# Altium schematic sheet motor_controller_relays.SchDoc(motor_controller_relays)
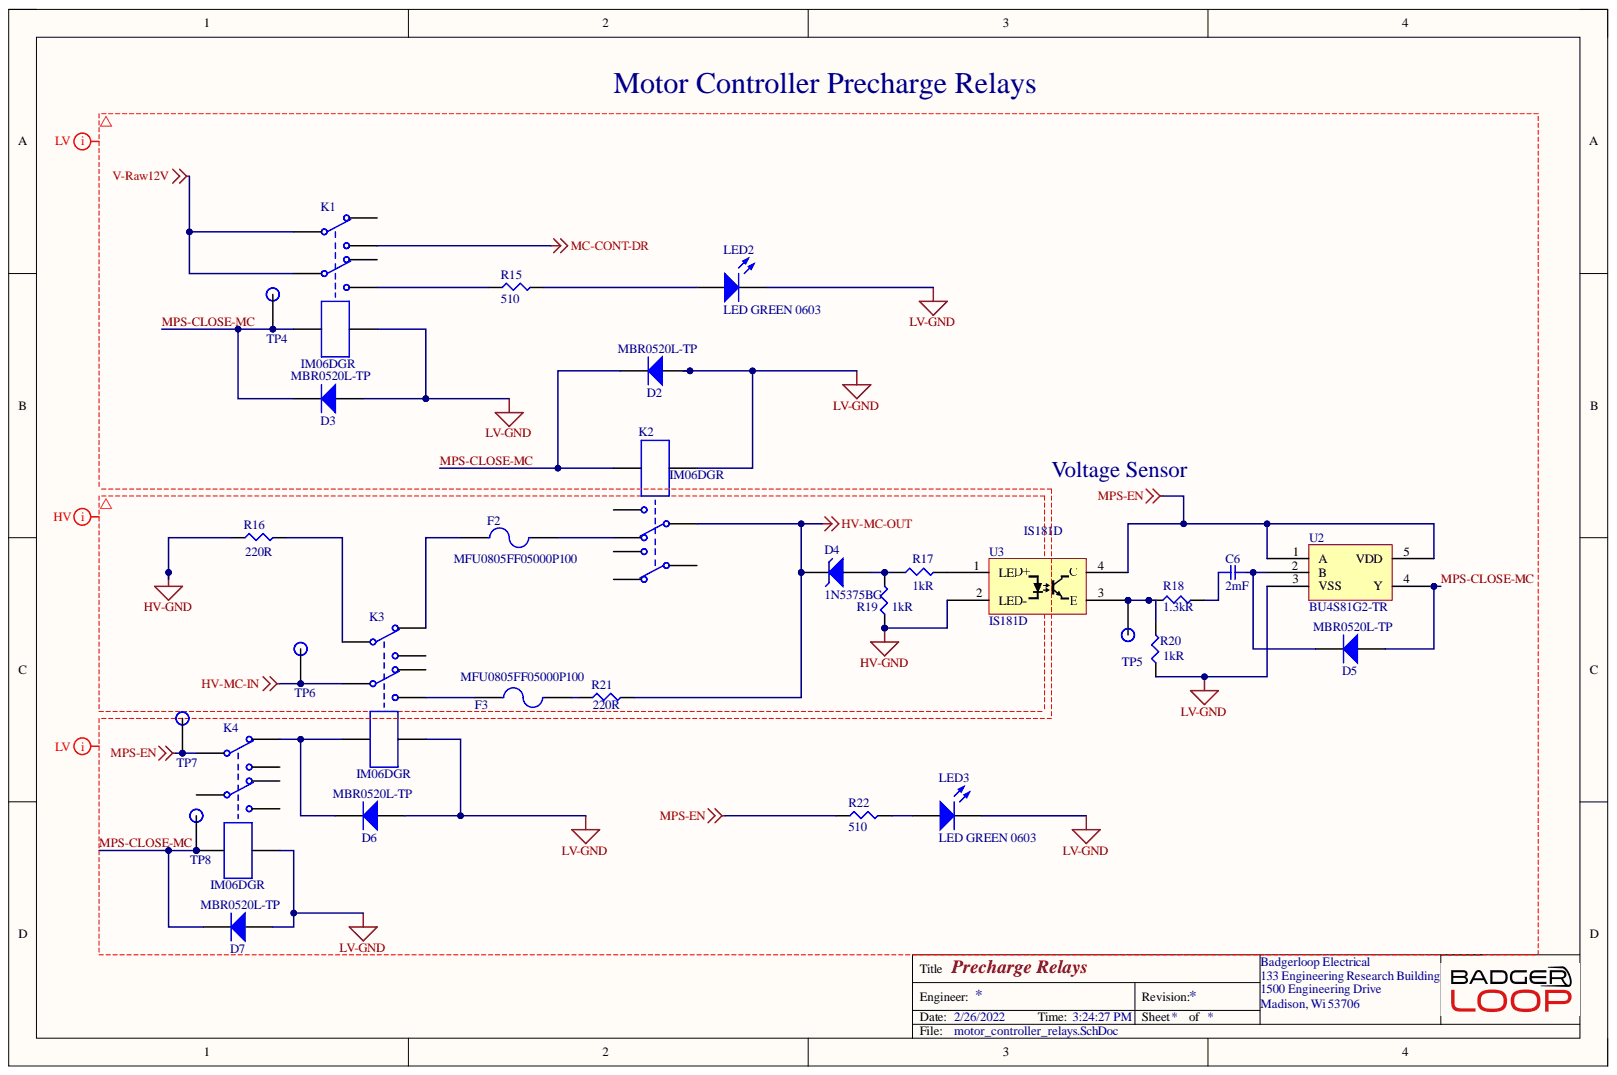
[diagram p0: motor_controller_relays.SchDoc]

## Parts
C6  Capacitance: 2mF  [EEU-TP1E202S]  (hidden comment: EEU-TP1E202S)
D2  MBR0520L-TP  [MBR0520LT1G - SOD123]
D3  MBR0520L-TP  [MBR0520LT1G - SOD123]
D4  1N5375BG  [1N5375BG]
D5  MBR0520L-TP  [MBR0520LT1G - SOD123]
D6  MBR0520L-TP  [MBR0520LT1G - SOD123]
D7  MBR0520L-TP  [MBR0520LT1G - SOD123]
F2  MFU0805FF05000P100  [SMD-0805-RES]
F3  MFU0805FF05000P100  [SMD-0805-RES]
K1  Manufacturer Part Number: IM06DGR  [1-1462039-8 - relay]  (hidden comment: 1-1462039-8)
K2  Manufacturer Part Number: IM06DGR  [1-1462039-8 - relay]  (hidden comment: 1-1462039-8)
K3  Manufacturer Part Number: IM06DGR  [1-1462039-8 - relay]  (hidden comment: 1-1462039-8)
K4  Manufacturer Part Number: IM06DGR  [1-1462039-8 - relay]  (hidden comment: 1-1462039-8)
LED2  LED GREEN 0603  Color: GREEN  [LED 0603 GREEN]
LED3  LED GREEN 0603  Color: GREEN  [LED 0603 GREEN]
R15  RMCF1206FT510R  [SMD-1206-RES]  (value not drawn)
R16  Resistance: 220R  [TO-247-2]  (hidden comment: AP101220RJ)
R17  Resistance: 1kR  [SMD-1206-RES]  (hidden comment: D55342K07B1E00RS3)
R18  Resistance: 1.3kR  [SMD-1206-RES]  (hidden comment: ERA-8AEB132V)
R19  Resistance: 1kR  [SMD-1206-RES]  (hidden comment: D55342K07B1E00RS3)
R20  Resistance: 1kR  [SMD-1206-RES]  (hidden comment: D55342K07B1E00RS3)
R21  Resistance: 220R  [TO-247-2]  (hidden comment: AP101220RJ)
R22  RMCF1206FT510R  [SMD-1206-RES]  (value not drawn)
TP4  TP  [Test Point]
TP5  TP  [Test Point]
TP6  TP  [Test Point]
TP7  TP  [Test Point]
TP8  TP  [Test Point]
U2  BU4S81G2-TR  [BU4S81G2]
U3  IS181D  [IS181D]

## Nets
HV-GND: R16.1 R19.1 U3.2(LED-)
HV-MC-IN: K3.6 TP6.1
HV-MC-OUT: D4.1 K2.6 R21.2
LV-GND: D2.1 D3.1 D6.1 D7.1 K1.8 K2.1 K3.8 K4.8 LED2.2 LED3.2 R20.1 U2.3(VSS)
MC-CONT-DR: K1.4
MPS-EN: K4.3 R22.1 TP7.1 U2.1(A) U2.5(VDD) U3.4(C)
V-Raw12V: K1.3 K1.6
MPS-CLOSE-MC: D2.2 D3.2 D5.1 D7.2 K1.1 K2.8 K4.1 TP4.1 TP8.1 U2.4(Y)
NetC6_1: C6.1 R18.2
NetC6_2: C6.2 D5.2 U2.2(B)
NetD4_2: D4.2 R17.1 R19.2
NetD6_2: D6.2 K3.1 K4.2
NetF2_1: F2.1 K3.2
NetF2_2: F2.2 K2.7
NetF3_1: F3.1 K3.5
NetF3_2: F3.2 R21.1
NetK1_5: K1.5 R15.1
NetK3_3: K3.3 R16.2
NetLED2_1: LED2.1 R15.2
NetLED3_1: LED3.1 R22.2
NetR17_2: R17.2 U3.1(LED+)
NetR18_1: R18.1 R20.2 TP5.1 U3.3(E)

Not connected: K1 2 7; K2 2 3 4 5; K3 4 7; K4 4 5 6 7

## Library data of the parts
C6 = EEU-TP1E202S: Aluminum Electrolytic Capacitor, 25V, 2000uF; Manufacturer: Panasonic; Capacitor Type: Aluminium; ESR (Equivalent Series Resistance): 0.029mR; Leakage Current: 500uA; Negative Tolerance: 20%; Polarity: Polarized; Reference Standard: AEC-Q200; Ripple Current: 1.588A; Tan Delta: 0.16; Category: Aluminum Electrolytic Capacitors; Component Kind: Standard; ComponentLink1Description: https://datasheet.ciiva.com:443/pdfs/VipMasterIC/IC/PANA/PANA-S-A0009459718/PANA-S-A0009459718-1.pdf?src-supplier=IHS+Markit; ComponentLink1URL: https://datasheet.ciiva.com:443/pdfs/VipMasterIC/IC/PANA/PANA-S-A0009459718/PANA-S-A0009459718-1.pdf?src-supplier=IHS+Markit; ComponentLink2Description: https://datasheet.ciiva.com/11784/0900766b80f8045d-11784485.pdf?src-supplier=RSComponents; ComponentLink2URL: https://datasheet.ciiva.com/11784/0900766b80f8045d-11784485.pdf?src-supplier=RSComponents; ComponentLink3Description: https://datasheet.ciiva.com/14607/70257784-14607977.pdf?src-supplier=Allied+Electronics; ComponentLink3URL: https://datasheet.ciiva.com/14607/70257784-14607977.pdf?src-supplier=Allied+Electronics; ComponentLink4Description: https://datasheet.ciiva.com/11677/aba0000ce134-11677886.pdf?src-supplier=Avnet; ComponentLink4URL: https://datasheet.ciiva.com/11677/aba0000ce134-11677886.pdf?src-supplier=Avnet; Diameter: 16mm; Dielectric Material: Aluminium; JESD-609 Code: e3; Length: 20mm; Library Name: capacitors.SchLib; Manufacturer Part Number: EEU-TP1E202S; Max Operating Temperature: 135°C; Min Operating Temperature: -40°C; Mount: Through Hole; Number of Pins: 2; Package Style: RadialMeter; Packaging: Bulk; Positive Tolerance: 20%; Pricing 1: 1=3.19000, 10=2.58000, 50=2.25160, 100=1.97010, 500=1.50100, 1000=1.31338, 2500=1.26647, 5000=1.21956 (USD); Rated DC Voltage (URdc): 25V; REACH SVHC: Yes; RoHS: Yes; RoHS Compliant: Yes; Stock 1: 672; Supplier 1: Digi-Key; Supplier Part Number 1: P14922-ND; Terminal Finish: Tin; Terminal Pitch: 7.5mm; Termination: Wire
D2 D3 D5-D7 = MBR0520L-TP: Rectifier Diode, Schottky, 1 Phase, 1 Element, 0.5A, 20V V(RRM), Silicon; Manufacturer: MCC; Forward Voltage-Max (VF): 300mV; Max Output Current: 500mA; Max Power Dissipation: 410mW; Non-rep Pk Forward Current-Max: 5.5A; Number of Elements: 1; Package Body Material: Plastic; Qualification Status: Not Qualified; Time @ Peak Reflow Temperature-Max (s): 10s; Category: Rectifier Diodes; Component Kind: Standard; ComponentLink1Description: https://datasheet.ciiva.com:443/pdfs/VipMasterIC/IC/MCCC/MCCC-S-A0007607609/MCCC-S-A0007607609-1.pdf?src-supplier=IHS+Markit; ComponentLink1URL: https://datasheet.ciiva.com:443/pdfs/VipMasterIC/IC/MCCC/MCCC-S-A0007607609/MCCC-S-A0007607609-1.pdf?src-supplier=IHS+Markit; ComponentLink2Description: https://datasheet.ciiva.com/24106/mbr0520lmbr0540lsod-123-276512-24106653.pdf?src-supplier=Mouser; ComponentLink2URL: https://datasheet.ciiva.com/24106/mbr0520lmbr0540lsod-123-276512-24106653.pdf?src-supplier=Mouser; ComponentLink3Description: https://datasheet.ciiva.com/6381/mbr0520lmbr0540lsod-123-6381109.pdf?src-supplier=Digi-Key; ComponentLink3URL: https://datasheet.ciiva.com/6381/mbr0520lmbr0540lsod-123-6381109.pdf?src-supplier=Digi-Key; Diode Element Material: SILICON; Diode Type: RECTIFIER; JESD-30 Code: R-PDSO-G2; JESD-609 Code: e3; Library Name: misc.SchLib; Manufacturer Part Number: MBR0520L-TP; Max Operating Temperature: 125°C; Moisture Sensitivity Level: 1; Number of Pins: 2; Package Shape: Rectangular; Package Style: SMALL OUTLINEMeter; Peak Reflow Temperature (Cel): 260°C; Pricing 1: 1=0.52000, 10=0.38700, 100=0.21930, 500=0.14520, 1000=0.11132 (USD); REACH SVHC: Yes; Rep Pk Reverse Voltage-Max: 20V; RoHS: Yes; RoHS Compliant: Yes; Stock 1: 75810; Supplier 1: Digi-Key; Supplier Part Number 1: MBR0520L-TPMSCT-ND; Surface Mount: Yes; Technology: SCHOTTKY; Terminal Finish: Tin; Terminal Form: Gull Wing; Terminal Position: DUAL
D4 = 1N5375BG: Zener Diode, 82V V(Z), 5%, 5W, Silicon, Unidirectional; Manufacturer: ON Semiconductor; Case Connection: Isolated; Dynamic Impedance-Max: 65R; Number of Elements: 1; Package Body Material: Plastic; Qualification Status: Not Qualified; Surface Mount: No; Test Current: 15mA; Time @ Peak Reflow Temperature-Max (s): 40s; Category: Zener Diodes; Component Kind: Standard; ComponentLink1Description: https://datasheet.ciiva.com:443/pdfs/VipMasterIC/IC/ONSM/ONSMS36970/ONSMS36970-1.pdf?src-supplier=IHS+Markit; ComponentLink1URL: https://datasheet.ciiva.com:443/pdfs/VipMasterIC/IC/ONSM/ONSMS36970/ONSMS36970-1.pdf?src-supplier=IHS+Markit; ComponentLink2Description: https://datasheet.ciiva.com/30478/0900766b811a6372-30478537.pdf?src-supplier=RSComponents; ComponentLink2URL: https://datasheet.ciiva.com/30478/0900766b811a6372-30478537.pdf?src-supplier=RSComponents; ComponentLink3Description: https://datasheet.ciiva.com/15142/getdatasheetpartid-72158-15142393.pdf?src-supplier=Verical; ComponentLink3URL: https://datasheet.ciiva.com/15142/getdatasheetpartid-72158-15142393.pdf?src-supplier=Verical; ComponentLink4Description: https://datasheet.ciiva.com/895/1n5333b-d-895438.pdf?src-supplier=Digi-Key; ComponentLink4URL: https://datasheet.ciiva.com/895/1n5333b-d-895438.pdf?src-supplier=Digi-Key; ComponentLink5Description: https://datasheet.ciiva.com/8345/1n5333b-d-8345830.pdf?src-supplier=Avnet; ComponentLink5URL: https://datasheet.ciiva.com/8345/1n5333b-d-8345830.pdf?src-supplier=Avnet; ComponentLink6Description: https://datasheet.ciiva.com/1182/56785-1182241.pdf?src-supplier=Element14; ComponentLink6URL: https://datasheet.ciiva.com/1182/56785-1182241.pdf?src-supplier=Element14; ComponentLink7Description: https://datasheet.ciiva.com/14558/70099451-14558283.pdf?src-supplier=Allied+Electronics; ComponentLink7URL: https://datasheet.ciiva.com/14558/70099451-14558283.pdf?src-supplier=Allied+Electronics; ComponentLink8Description: https://datasheet.ciiva.com/46425/1n5333b-d-46425850.pdf?src-supplier=Element14; ComponentLink8URL: https://datasheet.ciiva.com/46425/1n5333b-d-46425850.pdf?src-supplier=Element14; Diode Element Material: SILICON; Diode Type: ZENER; JESD-30 Code: O-PALF-W2; JESD-609 Code: e3; Library Name: misc.SchLib; Max Operating Temperature: 200°C; Max Power Dissipation: 5W; Min Operating Temperature: -65°C; Number of Pins: 2; Package Shape: Round; Package Style: LONG FORMMeter; Peak Reflow Temperature (Cel): 260°C; Polarity: Unidirectional; Pricing 1: 1=0.47000, 10=0.40500, 100=0.30210, 500=0.23740, 1000=0.18344, 2000=0.17815 (USD); REACH SVHC: No SVHC; Reference Voltage-Nom: 82V; RoHS: Yes; RoHS Compliant: Yes; Stock 1: 1460; Supplier 1: Digi-Key; Supplier Part Number 1: 1N5375BGOS-ND; Technology: ZENER; Terminal Finish: Tin; Terminal Form: Wire; Terminal Position: AXIAL; Voltage Tol-Max: 5%
F2 F3 = MFU0805FF05000P100: Electric Fuse, Very Fast Blow, 5A, 32VDC, 50A (IR), Surface Mount; Manufacturer: Vishay; Body Breadth: 1.25inch; Body Height: 0.45mm; Body Length or Diameter: 2mm; Joule-integral-Nom: 0.2275J; Reference Standard: UL; Voltage Rating (DC): 32V; Blow Characteristic: VERY FAST; Category: Fuses; Circuit Protection Type: Electric Fuse; Component Kind: Standard; ComponentLink1Description: https://datasheet.ciiva.com:443/pdfs/VipMasterIC/IC/VISH/VISH-S-A0001809179/VISH-S-A0001809179-1.pdf?src-supplier=IHS+Markit; ComponentLink1URL: https://datasheet.ciiva.com:443/pdfs/VipMasterIC/IC/VISH/VISH-S-A0001809179/VISH-S-A0001809179-1.pdf?src-supplier=IHS+Markit; ComponentLink2Description: https://datasheet.ciiva.com/1730/mfuserie-1730720.pdf?src-supplier=Newark; ComponentLink2URL: https://datasheet.ciiva.com/1730/mfuserie-1730720.pdf?src-supplier=Newark; ComponentLink3Description: https://datasheet.ciiva.com/11337/mfuserie-11337721.pdf?src-supplier=Avnet; ComponentLink3URL: https://datasheet.ciiva.com/11337/mfuserie-11337721.pdf?src-supplier=Avnet; ComponentLink4Description: https://datasheet.ciiva.com/8057/1480005-8057917.pdf?src-supplier=Element14; ComponentLink4URL: https://datasheet.ciiva.com/8057/1480005-8057917.pdf?src-supplier=Element14; ComponentLink5Description: https://datasheet.ciiva.com/22734/1480005-22734093.pdf?src-supplier=Element14; ComponentLink5URL: https://datasheet.ciiva.com/22734/1480005-22734093.pdf?src-supplier=Element14; ComponentLink6Description: https://datasheet.ciiva.com/17414/mfuserie-17414775.pdf?src-supplier=Avnet+Europe; ComponentLink6URL: https://datasheet.ciiva.com/17414/mfuserie-17414775.pdf?src-supplier=Avnet+Europe; ComponentLink7Description: https://datasheet.ciiva.com/1730/mfuserie-1730721.pdf?src-supplier=Newark; ComponentLink7URL: https://datasheet.ciiva.com/1730/mfuserie-1730721.pdf?src-supplier=Newark; Current Rating: 5A; JESD-609 Code: e3; Library Name: misc.SchLib; Manufacturer Part Number: MFU0805FF05000P100; Max Operating Temperature: 35°C; Min Operating Temperature: 15°C; Mount: Surface Mount; Packaging: Tape and Reel; Pricing 1: 1=0.49000, 5=0.47600, 10=0.46200, 50=0.38880, 100=0.32270, 250=0.29340, 500=0.24940 (USD); Rated Breaking Capacity: 50A; REACH SVHC: Yes; RoHS: Yes; RoHS Compliant: Yes; Stock 1: 50547; Supplier 1: Digi-Key; Supplier Part Number 1: MFU08055.00CT-ND; Terminal Finish: Tin; Termination: Wraparound
K1-K4 = 1-1462039-8: Electromechanical Relay, DPDT, 140mW (Coil), 5A (Contact), 220VDC (Contact), Random, AC/DC Output; Manufacturer: TE Connectivity; Body Breadth: 6inch; Body Height: 5.65mm; Body Length or Diameter: 10mm; Coil Operate Voltage(DC): 9V; Coil Release Voltage(DC): 1.2V; Coil Resistance: 1.029kR; Coil Voltage-Nom: 12V; Coil/Input Supply Type: DC; Contact AC Max Power Rating R Load: 62.5VA@250VAC; Contact AC Max Rating R Load: 5A@250VAC; Contact DC Max Power Rating R Load: 60W@220VDC; Contact DC Max Rating R Load: 5A@220VDC; Contact Resistance: 50mR; Contact Voltage(AC)-Max: 250V; Contact Voltage(DC)-Max: 220V; Dielectric Strength Between Coil and Contacts: 1500Vrms; Dielectric Strength Between Open Contacts: 750Vrms; End Contact Material: Silver; End Contact Plating: Gold; Insulation Resistance: 1GR; Operate Time: 3ms; Reference Standard: UL; Relay Form: 2 FORM C; Sealing: HERMETICAL; Category: Power Relays; Coil Power: 140mW; Component Kind: Standard; ComponentLink1Description: https://datasheet.ciiva.com:443/pdfs/VipMasterIC/IC/TYEL/TYELS75403/TYELS75403-1.pdf?src-supplier=IHS+Markit; ComponentLink1URL: https://datasheet.ciiva.com:443/pdfs/VipMasterIC/IC/TYEL/TYELS75403/TYELS75403-1.pdf?src-supplier=IHS+Markit; ComponentLink2Description: https://datasheet.ciiva.com/1662/13778-1662593.pdf?src-supplier=Newark; ComponentLink2URL: https://datasheet.ciiva.com/1662/13778-1662593.pdf?src-supplier=Newark; ComponentLink3Description: https://datasheet.ciiva.com/1525/1717896-1525047.pdf?src-supplier=Element14; ComponentLink3URL: https://datasheet.ciiva.com/1525/1717896-1525047.pdf?src-supplier=Element14; ComponentLink4Description: https://datasheet.ciiva.com/1612/1717896-1612285.pdf?src-supplier=Farnell; ComponentLink4URL: https://datasheet.ciiva.com/1612/1717896-1612285.pdf?src-supplier=Farnell; ComponentLink5Description: https://datasheet.ciiva.com/30159/customerdrawing1462037-4a10-30159339.pdf?src-supplier=Onlinecomponents.com; ComponentLink5URL: https://datasheet.ciiva.com/30159/customerdrawing1462037-4a10-30159339.pdf?src-supplier=Onlinecomponents.com; ComponentLink6Description: https://datasheet.ciiva.com/22697/1717896-22697038.pdf?src-supplier=Element14; ComponentLink6URL: https://datasheet.ciiva.com/22697/1717896-22697038.pdf?src-supplier=Element14; Contact Current(AC)-Max: 5A; Contact Current(DC)-Max: 5A; Contact/Output Supply Type: AC/DC; Input Switching Control Type: Random; Library Name: misc.SchLib; Number of Pins: 8; Packaging: Tape and Reel; Pricing 1: 1=5.59000, 10=5.24500, 25=4.66200, 50=4.42880, 100=4.19580, 250=3.72960, 500=3.49650 (USD); REACH SVHC: No SVHC; Relay Function: DPDT; RoHS: No; RoHS Compliant: No; Stock 1: 35148; Supplier 1: Digi-Key; Supplier Part Number 1: PB1174CT-ND; Termination: Solder; Weight: 0.75g
LED2 LED3 = LED GREEN 0603: Manufacturer: Lite-On Inc.; Manufacturer P/N: LTST-C190KGKT; Component Kind: Standard; Digikey P/N: 160-1435-1-ND; Library Name: misc.SchLib; Package / Case: 0603
R15 R22 = RMCF1206FT510R: Fixed Resistor, Metal Glaze/thick Film, 0.25W, 510ohm, 200V, 1% +/-Tol, 100ppm/Cel, Surface Mount, 1206; Manufacturer: Stackpole Electronics; Package Height: 0.55mm; Package Length: 3.2mm; Package Width: 1.6mm; Rated Power Dissipation (P): 250mW; Rated Temperature: 70°C; Reference Standard: AEC-Q200; Resistance: 510; Temperature Coefficient: 100ppm/°C; Category: Chip SMD Resistors; Component Kind: Standard; ComponentLink1Description: https://datasheet.ciiva.com:443/pdfs/VipMasterIC/IC/SEIE/SEIE-S-A0009541969/SEIE-S-A0009541969-1.pdf?src-supplier=IHS+Markit; ComponentLink1URL: https://datasheet.ciiva.com:443/pdfs/VipMasterIC/IC/SEIE/SEIE-S-A0009541969/SEIE-S-A0009541969-1.pdf?src-supplier=IHS+Markit; ComponentLink2Description: http://components.arrow.com/part/dataview/40948710S9551678N1174?src-supplier=Arrow+Electronics; ComponentLink2URL: http://components.arrow.com/part/dataview/40948710S9551678N1174?src-supplier=Arrow+Electronics; ComponentLink3Description: https://www.seielect.com/Catalog/SEI-RMCF_RMCP.pdf?src-supplier=Digi-Key; ComponentLink3URL: https://www.seielect.com/Catalog/SEI-RMCF_RMCP.pdf?src-supplier=Digi-Key; ComponentLink4Description: https://www.seielect.com/catalog/SEI-Packaging.pdf?src-supplier=Digi-Key; ComponentLink4URL: https://www.seielect.com/catalog/SEI-Packaging.pdf?src-supplier=Digi-Key; ComponentLink5Description: https://www.seielect.com/catalog/SEI-RMCF_RMCP.pdf?src-supplier=Avnet; ComponentLink5URL: https://www.seielect.com/catalog/SEI-RMCF_RMCP.pdf?src-supplier=Avnet; Construction: Rectangular; JESD-609 Code: e3; Library Name: resistors.SchLib; Manufacturer Part Number: RMCF1206FT510R; Max Operating Temperature: 155°C; Min Operating Temperature: -55°C; Mount: Surface Mount; Number of Pins: 2; Package Shape: Rectangular; Package Style: SMTMeter; Packaging: Tape and Reel; Pricing 1: 1=0.10000, 10=0.03600, 100=0.01460, 1000=0.00655, 2500=0.00569 (USD); REACH SVHC: Yes; Resistor Type: FIXED RESISTOR; RoHS: Yes; RoHS Compliant: Yes; Size Code: 1206; Stock 1: 51; Supplier 1: Digi-Key; Supplier Part Number 1: RMCF1206FT510RCT-ND; Technology: METAL GLAZE/THICK FILM; Terminal Finish: Nickel; Termination: Wraparound; Tolerance: 1%; Working Voltage: 200V
R16 R21 = AP101220RJ: Fixed Resistor, 100W, 220ohm, 700V, 5% +/-Tol, 100ppm/Cel, Through Hole Mount; Manufacturer: Ohmite; Rated Power Dissipation (P): 3.5W; Rated Temperature: 25°C; Temperature Coefficient: 100ppm/°C; Category: Through-Hole Resistors; Component Kind: Standard; ComponentLink1Description: https://datasheet.ciiva.com:443/pdfs/VipMasterIC/IC/OMIT/OMIT-S-A0001298920/OMIT-S-A0001298920-1.pdf?src-supplier=IHS+Markit; ComponentLink1URL: https://datasheet.ciiva.com:443/pdfs/VipMasterIC/IC/OMIT/OMIT-S-A0001298920/OMIT-S-A0001298920-1.pdf?src-supplier=IHS+Markit; ComponentLink2Description: http://www.farnell.com/datasheets/2015590.pdf?src-supplier=Farnell; ComponentLink2URL: http://www.farnell.com/datasheets/2015590.pdf?src-supplier=Farnell; ComponentLink3Description: http://www.mouser.com/ds/2/303/acl_ap101-773331.pdf?src-supplier=Mouser; ComponentLink3URL: http://www.mouser.com/ds/2/303/acl_ap101-773331.pdf?src-supplier=Mouser; ComponentLink4Description: http://www.ohmite.com/cat/acl_ap101.pdf?src-supplier=Digi-Key; ComponentLink4URL: http://www.ohmite.com/cat/acl_ap101.pdf?src-supplier=Digi-Key; Library Name: resistors.SchLib; Manufacturer Part Number: AP101220RJ; Max Operating Temperature: 175°C; Min Operating Temperature: -65°C; Mount: Through Hole; Number of Pins: 2; Package Shape: Rectangular; Pricing 1: 1=6.63000, 10=6.21800, 35=5.80343, 70=5.11271, 105=4.42171, 245=4.00722, 455=3.73086, 945=3.67501 (USD); REACH SVHC: Yes; Resistor Type: FIXED RESISTOR; RoHS: Yes; RoHS Compliant: Yes; Stock 1: 44; Supplier 1: Digi-Key; Supplier Part Number 1: AP101220RJ-ND; Termination: Flat; Tolerance: 5%; Working Voltage: 700V
R17 R19 R20 = D55342K07B1E00RS3: 0.25W, 1000ohm, 100V, 1% +/-Tol, 100ppm/Cel, Surface Mount, 1206; Manufacturer: Vishay Dale; Package Height: 0.51mm; Package Length: 3.18mm; Package Width: 1.6mm; Rated Power Dissipation (P): 250mW; Rated Temperature: 70°C; Reference Standard: MIL-PRF-55342; Temperature Coefficient: 100ppm/°C; Category: Chip SMD Resistors; Component Kind: Standard; ComponentLink1Description: https://datasheet.ciiva.com:443/pdfs/VipMasterIC/IC/VISH/VISH-S-A0002923714/VISH-S-A0002923714-1.pdf?src-supplier=IHS+Markit; ComponentLink1URL: https://datasheet.ciiva.com:443/pdfs/VipMasterIC/IC/VISH/VISH-S-A0002923714/VISH-S-A0002923714-1.pdf?src-supplier=IHS+Markit; ComponentLink2Description: https://datasheet.ciiva.com/2528/rcwpm-2528500.pdf?src-supplier=Digi-Key; ComponentLink2URL: https://datasheet.ciiva.com/2528/rcwpm-2528500.pdf?src-supplier=Digi-Key; Construction: Chip; JESD-609 Code: e0; Library Name: resistors.SchLib; Max Operating Temperature: 150°C; Min Operating Temperature: -55°C; Mount: Surface Mount; Number of Pins: 2; Package Shape: Rectangular; Package Style: SMTMeter; Packaging: Tape and Reel; Pricing 1: 1=1.73000, 10=1.52900, 100=1.11000 (USD); REACH SVHC: No SVHC; Resistor Type: FIXED RESISTOR; RoHS: No; RoHS Compliant: No; Series: RCWPM-RM1206; Size Code: 1206; Stock 1: 911; Supplier 1: Digi-Key; Supplier Part Number 1: 1135-1035-1-ND; Technology: METAL GLAZE/THICK FILM; Terminal Finish: Nickel; Termination: Wraparound; Tolerance: 1%; Working Voltage: 100V
R18 = ERA-8AEB132V: 0.25W, 1300ohm, 150V, 0.1% +/-Tol, 25ppm/Cel, Surface Mount, 1206; Manufacturer: Panasonic; Package Height: 0.6mm; Package Length: 3.2mm; Package Width: 1.6mm; Rated Power Dissipation (P): 250mW; Rated Temperature: 85°C; Reference Standard: AEC-Q200; Temperature Coefficient: 25ppm/°C; Category: Chip SMD Resistors; Component Kind: Standard; ComponentLink1Description: https://datasheet.ciiva.com:443/pdfs/VipMasterIC/IC/PANA/PANA-S-A0009459258/PANA-S-A0009459258-1.pdf?src-supplier=IHS+Markit; ComponentLink1URL: https://datasheet.ciiva.com:443/pdfs/VipMasterIC/IC/PANA/PANA-S-A0009459258/PANA-S-A0009459258-1.pdf?src-supplier=IHS+Markit; ComponentLink2Description: https://datasheet.ciiva.com/48529/era-eng-tds-48529610.pdf?src-supplier=Distrelec; ComponentLink2URL: https://datasheet.ciiva.com/48529/era-eng-tds-48529610.pdf?src-supplier=Distrelec; ComponentLink3Description: https://datasheet.ciiva.com/46387/391390-46387032.pdf?src-supplier=Element14; ComponentLink3URL: https://datasheet.ciiva.com/46387/391390-46387032.pdf?src-supplier=Element14; ComponentLink4Description: https://datasheet.ciiva.com/6137/391390-6137471.pdf?src-supplier=Farnell; ComponentLink4URL: https://datasheet.ciiva.com/6137/391390-6137471.pdf?src-supplier=Farnell; ComponentLink5Description: https://datasheet.ciiva.com/24037/aoa0000ce26-197354-24037543.pdf?src-supplier=Mouser; ComponentLink5URL: https://datasheet.ciiva.com/24037/aoa0000ce26-197354-24037543.pdf?src-supplier=Mouser; ComponentLink6Description: https://datasheet.ciiva.com/9198/aoa0000ce26-9198437.pdf?src-supplier=Avnet; ComponentLink6URL: https://datasheet.ciiva.com/9198/aoa0000ce26-9198437.pdf?src-supplier=Avnet; ComponentLink7Description: https://datasheet.ciiva.com/17214/aoa0000ce26-17214873.pdf?src-supplier=Avnet+Europe; ComponentLink7URL: https://datasheet.ciiva.com/17214/aoa0000ce26-17214873.pdf?src-supplier=Avnet+Europe; Construction: Rectangular; JESD-609 Code: e3; Library Name: resistors.SchLib; Manufacturer Part Number: ERA-8AEB132V; Max Operating Temperature: 155°C; Min Operating Temperature: -55°C; Mount: Surface Mount; Number of Pins: 2; Package Style: SMTMeter; Packaging: Tape and Reel; Pricing 1: 1=0.66000, 10=0.47200, 100=0.24550, 1000=0.13218, 2500=0.12746 (USD); REACH SVHC: Yes; Resistor Type: FIXED RESISTOR; RoHS: Yes; RoHS Compliant: Yes; Size Code: 1206; Stock 1: 10993; Supplier 1: Digi-Key; Supplier Part Number 1: P1.3KBCCT-ND; Technology: THIN FILM; Terminal Finish: Nickel; Termination: Wraparound; Tolerance: 0.1%; Working Voltage: 150V
TP4-TP8 = TP: SMD TEST POINT; Component Kind: Standard; Library Name: misc.SchLib
U2 = BU4S81G2-TR: AND Gate, 4000/14000/40000 Series, 1-Func, 2-Input, CMOS, PDSO5; Manufacturer: Rohm; Load Capacitance (CL): 50pF; Number of Functions: 1; Number of Inputs: 2; Package Body Material: Plastic; Package Equivalence Code: TSOP5/6,.11,37; Power Supplies: 3/16V; Qualification Status: Not Qualified; Schmitt Trigger: No; Supply Voltage-Max (Vsup): 16V; Supply Voltage-Min (Vsup): 3V; Supply Voltage-Nom (Vsup): 5V; Category: Logic Gates; Component Kind: Standard; ComponentLink1Description: https://datasheet.ciiva.com:443/pdfs/VipMasterIC/IC/ROHM/ROHM-S-A0001749750/ROHM-S-A0001749750-1.pdf?src-supplier=IHS+Markit; ComponentLink1URL: https://datasheet.ciiva.com:443/pdfs/VipMasterIC/IC/ROHM/ROHM-S-A0001749750/ROHM-S-A0001749750-1.pdf?src-supplier=IHS+Markit; ComponentLink2Description: https://datasheet.ciiva.com/12254/bu4s81g2-e-209681-12254411.pdf?src-supplier=Mouser; ComponentLink2URL: https://datasheet.ciiva.com/12254/bu4s81g2-e-209681-12254411.pdf?src-supplier=Mouser; ComponentLink3Description: https://datasheet.ciiva.com/1508/bu4s81g2-e-1508101.pdf?src-supplier=Element14; ComponentLink3URL: https://datasheet.ciiva.com/1508/bu4s81g2-e-1508101.pdf?src-supplier=Element14; ComponentLink4Description: https://datasheet.ciiva.com/1508/bu4s81g2-e-1508102.pdf?src-supplier=Element14; ComponentLink4URL: https://datasheet.ciiva.com/1508/bu4s81g2-e-1508102.pdf?src-supplier=Element14; ComponentLink5Description: https://datasheet.ciiva.com/8274/bu4s01g2-e-8274597.pdf?src-supplier=Avnet; ComponentLink5URL: https://datasheet.ciiva.com/8274/bu4s01g2-e-8274597.pdf?src-supplier=Avnet; ComponentLink6Description: https://datasheet.ciiva.com/6619/bu4s01g2-e-6619800.pdf?src-supplier=Digi-Key; ComponentLink6URL: https://datasheet.ciiva.com/6619/bu4s01g2-e-6619800.pdf?src-supplier=Digi-Key; ComponentLink7Description: https://datasheet.ciiva.com/6619/bu4s01g2-e-6619813.pdf?src-supplier=Digi-Key; ComponentLink7URL: https://datasheet.ciiva.com/6619/bu4s01g2-e-6619813.pdf?src-supplier=Digi-Key; ComponentLink8Description: https://datasheet.ciiva.com/6619/bu4s01g2-e-6619814.pdf?src-supplier=Digi-Key; ComponentLink8URL: https://datasheet.ciiva.com/6619/bu4s01g2-e-6619814.pdf?src-supplier=Digi-Key; ComponentLink9Description: https://datasheet.ciiva.com/16678/bu4s01g2-e-16678569.pdf?src-supplier=Avnet+Europe; ComponentLink9URL: https://datasheet.ciiva.com/16678/bu4s01g2-e-16678569.pdf?src-supplier=Avnet+Europe; Height - Seated (Max): 1.25mm; JESD-30 Code: R-PDSO-G5; Length: 2.9mm; Library Name: ic.SchLib; Logic IC Type: AND GATE; Manufacturer Part Number: BU4S81G2-TR; Max Operating Temperature: 85°C; Min Operating Temperature: -40°C; Moisture Sensitivity Level: 1; Number of Pins: 5; Package Code: LSSOP; Package Shape: Rectangular; Package Style: SMALL OUTLINE, LOW PROFILE, SHRINK PITCHMeter; Packaging: Tape and Reel; Pricing 1: 1=0.90000, 10=0.79200, 25=0.74400, 100=0.60720, 250=0.56396, 500=0.47996, 1000=0.38397 (USD); REACH SVHC: Yes; RoHS: Yes; RoHS Compliant: Yes; Stock 1: 2698; Supplier 1: Digi-Key; Supplier Part Number 1: BU4S81G2CT-ND; Surface Mount: Yes; Technology: CMOS; Temperature Grade: INDUSTRIAL; Terminal Form: Gull Wing; Terminal Pitch: 0.95mm; Terminal Position: DUAL; Width: 1.6mm
U3 = IS181D: Transistor Output Optocoupler; Manufacturer: Isocom; Category: Optocouplers; Component Kind: Standard; ComponentLink1Description: https://datasheet.ciiva.com:443/pdfs/VipMasterIC/IC/ISCL/ISCL-S-A0004892693/ISCL-S-A0004892693-1.pdf?src-supplier=IHS+Markit; ComponentLink1URL: https://datasheet.ciiva.com:443/pdfs/VipMasterIC/IC/ISCL/ISCL-S-A0004892693/ISCL-S-A0004892693-1.pdf?src-supplier=IHS+Markit; ComponentLink2Description: https://datasheet.ciiva.com/7996/90946-7996982.pdf?src-supplier=Element14; ComponentLink2URL: https://datasheet.ciiva.com/7996/90946-7996982.pdf?src-supplier=Element14; Library Name: ic.SchLib; Manufacturer Part Number: IS181D; Pricing 1: 1=0.59000, 10=0.36000, 100=0.20720, 1000=0.16218 (USD); RoHS: Yes; RoHS Compliant: Yes; Stock 1: 15104; Supplier 1: Digi-Key; Supplier Part Number 1: IS181DCT-ND
